# Altium schematic sheet AUX_measure_and_SCS_check.SchDoc(AUX_measure_and_SCS_check)
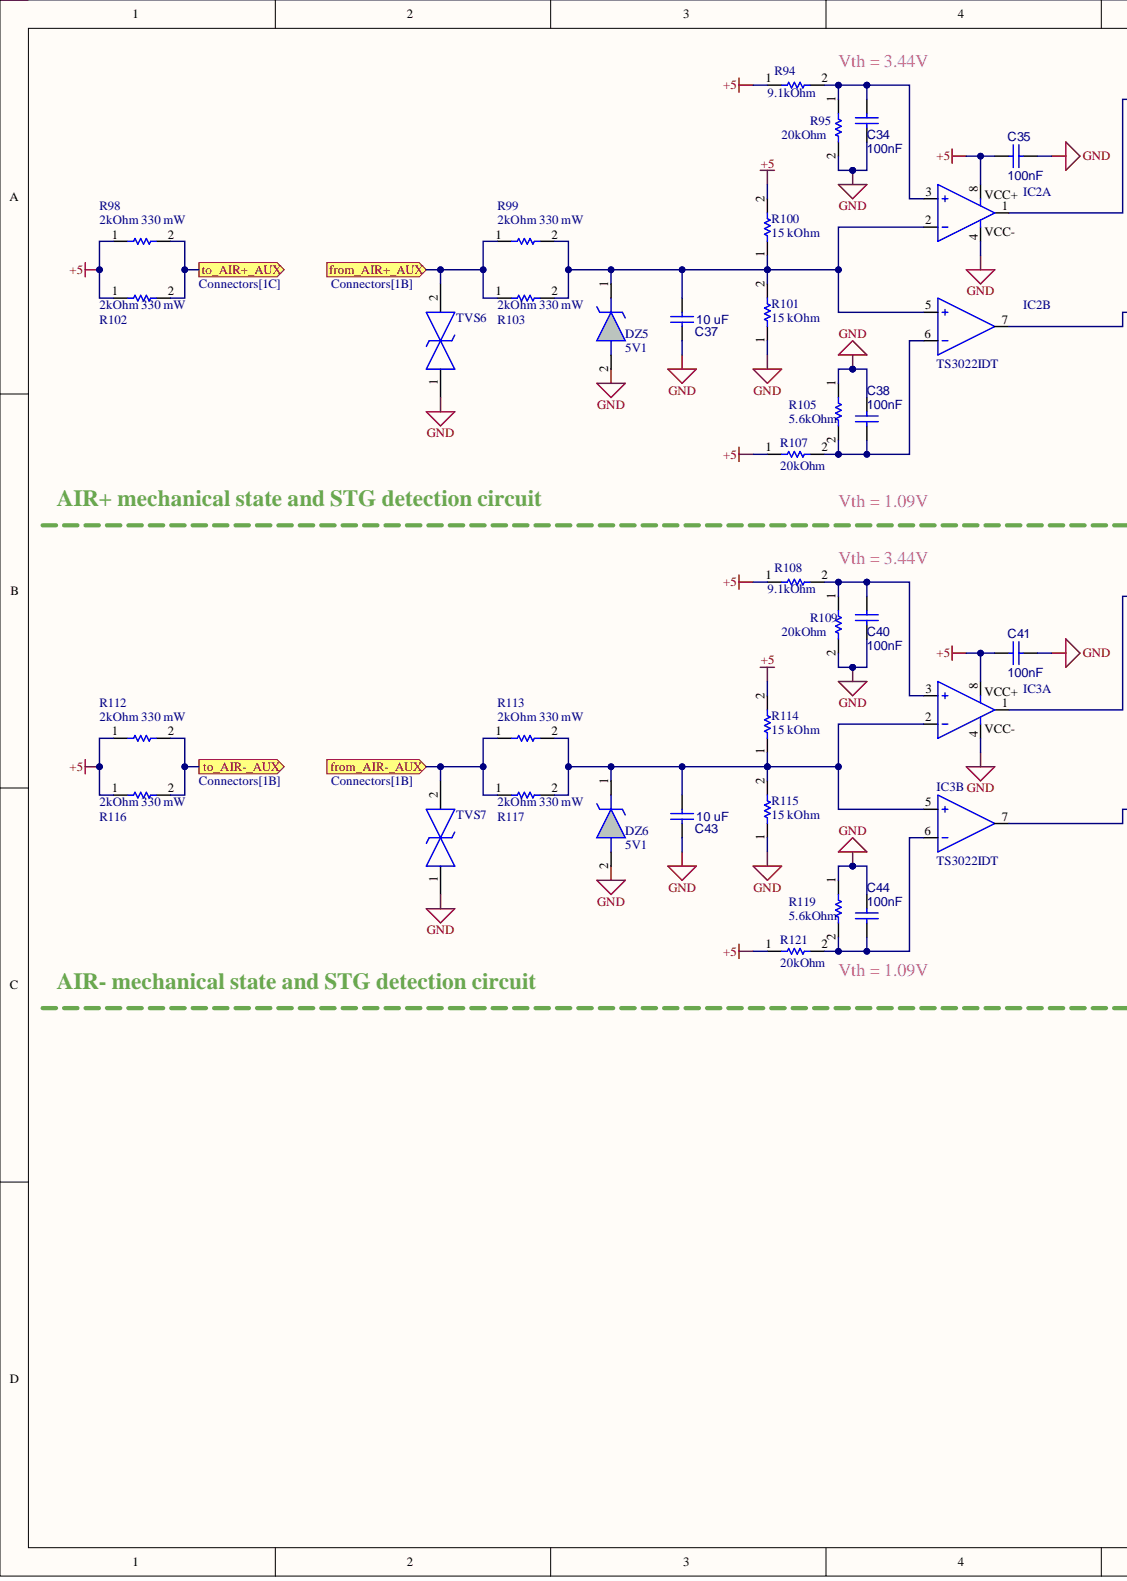
[diagram p0: AUX_measure_and_SCS_check.SchDoc, left]
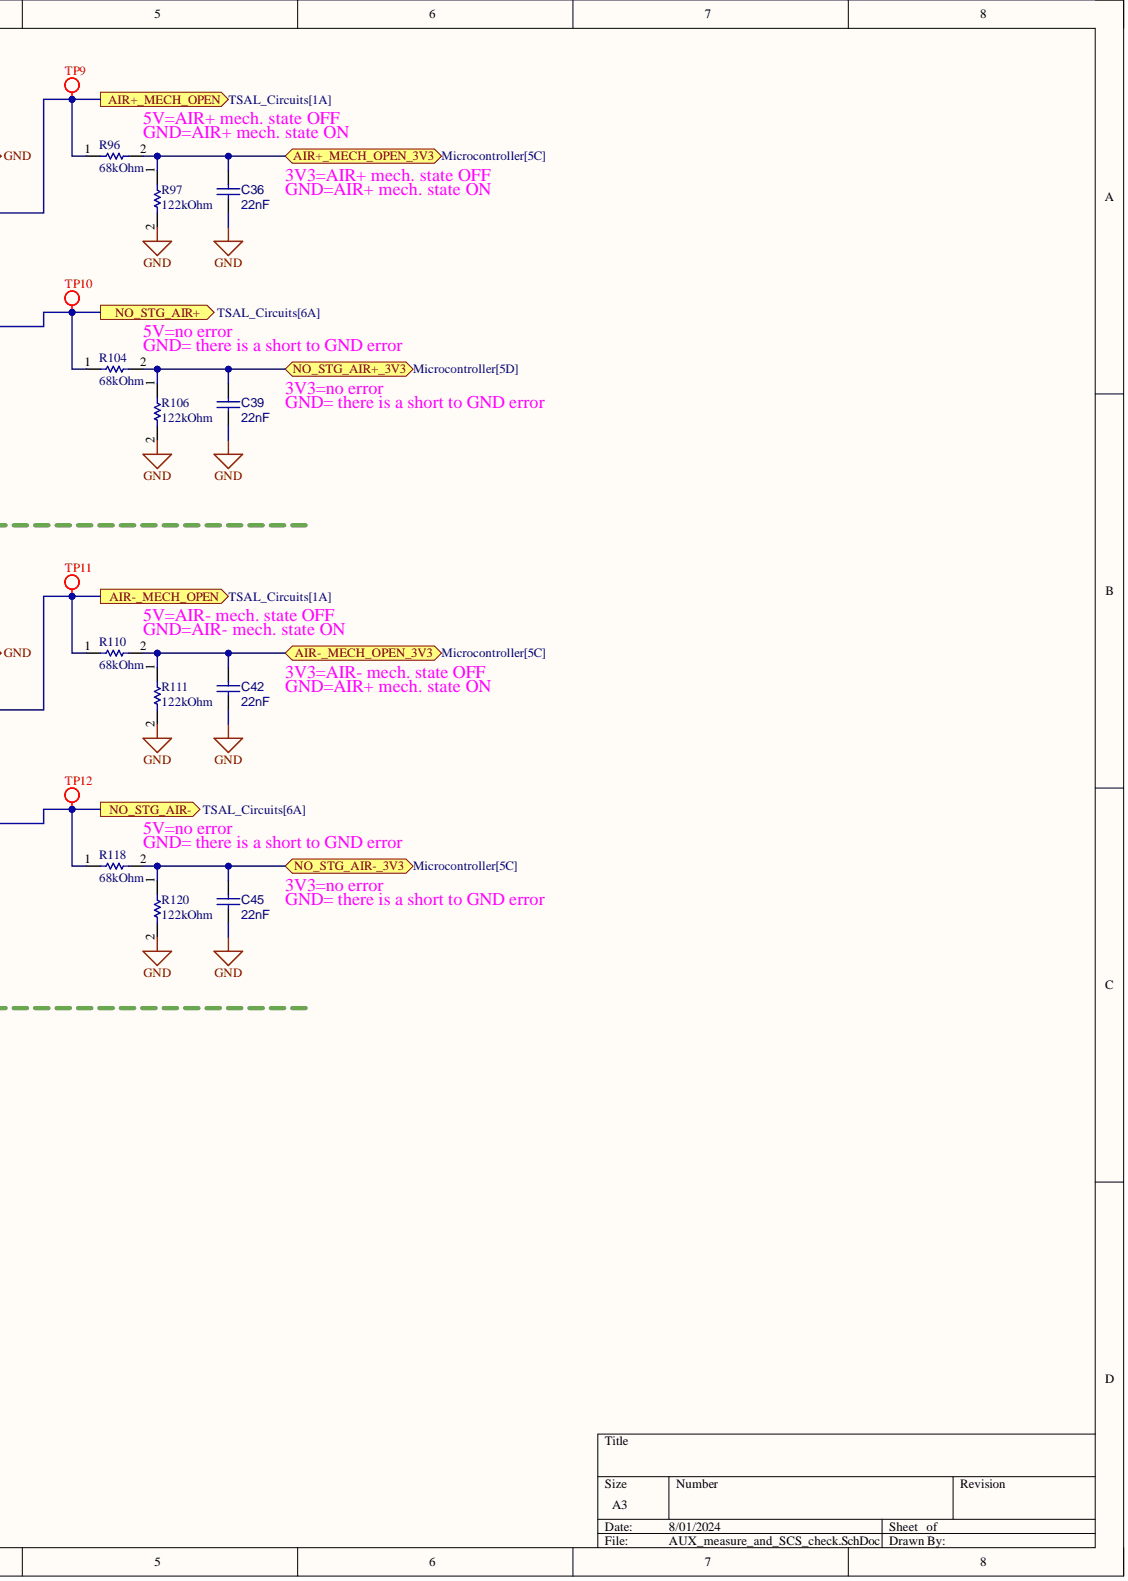
[diagram p1: AUX_measure_and_SCS_check.SchDoc, right]

## Parts
C34  100nF
C35  100nF
C36  22nF
C37  10 uF
C38  100nF
C39  22nF
C40  100nF
C41  100nF
C42  22nF
C43  10 uF
C44  100nF
C45  22nF
DZ5  5V1
DZ6  5V1
IC2  TS3022IDT  (parts A,B)
IC3  TS3022IDT  (parts A,B)
R94  9.1kOhm
R95  20kOhm
R96  68kOhm
R97  122kOhm
R98  2kOhm 330 mW
R99  2kOhm 330 mW
R100  15 kOhm
R101  15 kOhm
R102  2kOhm 330 mW
R103  2kOhm 330 mW
R104  68kOhm
R105  5.6kOhm
R106  122kOhm
R107  20kOhm
R108  9.1kOhm
R109  20kOhm
R110  68kOhm
R111  122kOhm
R112  2kOhm 330 mW
R113  2kOhm 330 mW
R114  15 kOhm
R115  15 kOhm
R116  2kOhm 330 mW
R117  2kOhm 330 mW
R118  68kOhm
R119  5.6kOhm
R120  122kOhm
R121  20kOhm
TP9  Test Point  (value not drawn)
TP10  Test Point  (value not drawn)
TP11  Test Point  (value not drawn)
TP12  Test Point  (value not drawn)
TVS6  SMAJ30CA-TR  (value not drawn)
TVS7  SMAJ30CA-TR  (value not drawn)

## Ports
AIR+_MECH_OPEN, AIR+_MECH_OPEN_3V3, AIR-_MECH_OPEN, AIR-_MECH_OPEN_3V3, from_AIR+_AUX, from_AIR-_AUX, NO_STG_AIR+, NO_STG_AIR+_3V3, NO_STG_AIR-, NO_STG_AIR-_3V3, to_AIR+_AUX, to_AIR-_AUX

## Nets
+5: C35.1 C41.1 IC2.8 IC3.8 R94.1 R98.1 R100.2 R102.1 R107.1 R108.1 R112.1 R114.2 R116.1 R121.1
GND: C34.2 C35.2 C36.1 C37.1 C38.2 C39.1 C40.2 C41.2 C42.1 C43.1 C44.2 C45.1 DZ5.2 DZ6.2 IC2.4 IC3.4 R95.2 R97.2 R101.1 R105.1 R106.2 R109.2 R111.2 R115.1 R119.1 R120.2 TVS6.1 TVS7.1
NetC34_1: C34.1 IC2.3 R94.2 R95.1
NetC36_2: C36.2 R96.2 R97.1
NetC37_2: C37.2 DZ5.1 IC2.2 IC2.5 R99.2 R100.1 R101.2 R103.2
NetC38_1: C38.1 IC2.6 R105.2 R107.2
NetC39_2: C39.2 R104.2 R106.1
NetC40_1: C40.1 IC3.3 R108.2 R109.1
NetC42_2: C42.2 R110.2 R111.1
NetC43_2: C43.2 DZ6.1 IC3.2 IC3.5 R113.2 R114.1 R115.2 R117.2
NetC44_1: C44.1 IC3.6 R119.2 R121.2
NetC45_2: C45.2 R118.2 R120.1
NetIC2_1: IC2.1 R96.1 TP9.1
NetIC2_7: IC2.7 R104.1 TP10.1
NetIC3_1: IC3.1 R110.1 TP11.1
NetIC3_7: IC3.7 R118.1 TP12.1
NetR98_2: R98.2 R102.2
NetR99_1: R99.1 R103.1 TVS6.2
NetR112_2: R112.2 R116.2
NetR113_1: R113.1 R117.1 TVS7.2

## Library data of the parts
C34 C35 C38 C40 C41 C44 = CMP-001-00085-5: VJ0805Y104MXATW1BC; Tech: SMD; Component Kind: Standard; ComponentLink1Description: Website; Package: 0805; Library Name: EMEA- Squadra Corse PoliTO-  Politecnico di Torino
C36 C39 C42 C45 = CMP-001-00085-5: VJ0805Y223JXACW1BC; Tech: SMD; Component Kind: Standard; ComponentLink1Description: Website; Package: 0805; Library Name: EMEA- Squadra Corse PoliTO-  Politecnico di Torino
C37 C43 = CMP-001-00085-5: GRM21BR71A106KA73K; Tech: SMD; Component Kind: Standard; ComponentLink1Description: Website; Package: 0805; Library Name: EMEA- Squadra Corse PoliTO-  Politecnico di Torino
DZ5 DZ6 = CMP-002-000008-4: MMSZ5231C-E3-08; Manufacturer_Name: Vishay; Geometry.Height: 1.35mm; Component Kind: Standard; Datasheet Link: https://www.arrow.com/en/products/mmsz5231c-e3-08/vishay; Design Item ID: MMSZ5231C-E3-08; Manufacturer_Part_Number: MMSZ5231C-E3-08; Mouser Part Number: 78-MMSZ5231C-E3-08; Mouser Price/Stock: https://www.mouser.co.uk/ProductDetail/Vishay-Semiconductors/MMSZ5231C-E3-08?qs=S660mHfF5o35EDHKMfkp8w%3D%3D; Source: MMSZ5231C-E3-08.SchLib; Library Name: EMEA- Squadra Corse PoliTO-  Politecnico di Torino
IC2 IC3 = CMP-030-000040-3: Manufacturer_Name: STMicroelectronics; Arrow Part Number: TS3022IDT; Arrow Price/Stock: https://www.arrow.com/en/products/ts3022idt/stmicroelectronics; Component Kind: Standard; Datasheet Link: http://www.st.com/st-web-ui/static/active/en/resource/technical/document/datasheet/CD00222487.pdf; Description: TS3022IDT; Design Item ID: TS3022IDT; Height: 1.75mm; Manufacturer_Part_Number: TS3022IDT; Mouser Part Number: 511-TS3022IDT; Mouser Price/Stock: https://www.mouser.co.uk/ProductDetail/STMicroelectronics/TS3022IDT?qs=%252BFmDY%2FmH7IbwI8iiFoislw%3D%3D; Source: SamacSys.SchLib; Library Name: EMEA- Squadra Corse PoliTO-  Politecnico di Torino
R94 R108 = CMP-009-00179-5: CRCW06039K10FKEA; Tech: SMD; Component Kind: Standard; ComponentLink1Description: Website; Package: 0603; Library Name: EMEA- Squadra Corse PoliTO-  Politecnico di Torino
R95 R107 R109 R121 = CMP-009-00179-5: CRCW060320K0FKEAC; Tech: SMD; Component Kind: Standard; ComponentLink1Description: Website; Package: 0603; Library Name: EMEA- Squadra Corse PoliTO-  Politecnico di Torino
R96 R104 R110 R118 = CMP-009-00179-5: CRCW060368K0FKEAC; Tech: SMD; Component Kind: Standard; ComponentLink1Description: Website; Package: 0603; Library Name: EMEA- Squadra Corse PoliTO-  Politecnico di Torino
R97 R106 R111 R120 = CMP-009-00179-5: CRCW0603121KFKEA; Tech: SMD; Component Kind: Standard; ComponentLink1Description: Website; Package: 0603; Library Name: EMEA- Squadra Corse PoliTO-  Politecnico di Torino
R98 R99 R102 R103 R112 R113 R116 R117 = CMP-009-00179-5: CRCW06032K00FKEAHP; Tech: SMD; Component Kind: Standard; ComponentLink1Description: Website; Package: 0603; Library Name: EMEA- Squadra Corse PoliTO-  Politecnico di Torino
R100 R101 R114 R115 = CMP-009-00179-5: CRCW060315K0FKTA; Tech: SMD; Component Kind: Standard; ComponentLink1Description: Website; Package: 0603; Library Name: EMEA- Squadra Corse PoliTO-  Politecnico di Torino
R105 R119 = CMP-009-00179-5: CRCW06035K60FKEA; Tech: SMD; Component Kind: Standard; ComponentLink1Description: Website; Package: 0603; Library Name: EMEA- Squadra Corse PoliTO-  Politecnico di Torino
TP9-TP12 = CMP-002-00071-2: Component Kind: Standard; Description: Test Point; Library Name: EMEA- Squadra Corse PoliTO-  Politecnico di Torino
TVS6 TVS7 = CMP-004-000005-1: TVS Diode Bi-Directional SMAJ30CA-TR 64.3V; Manufacturer_Name: STMicroelectronics; Arrow Part Number: SMAJ30CA-TR; Arrow Price/Stock: https://www.arrow.com/en/products/smaj30ca-tr/stmicroelectronics?region=nac; Component Kind: Standard; Datasheet Link: https://www.st.com/resource/en/datasheet/smaj10ca.pdf; Design Item ID: SMAJ30CA-TR; Height: 2.65mm; Manufacturer_Part_Number: SMAJ30CA-TR; Mouser Part Number: 511-SMAJ30CA-TR; Mouser Price/Stock: https://www.mouser.co.uk/ProductDetail/STMicroelectronics/SMAJ30CA-TR?qs=0TJjK1waviDY0EkLaKH12g%3D%3D; Source: SamacSys.SchLib; Library Name: EMEA- Squadra Corse PoliTO-  Politecnico di Torino
